# Altium schematic sheet Power.SchDoc(Power)
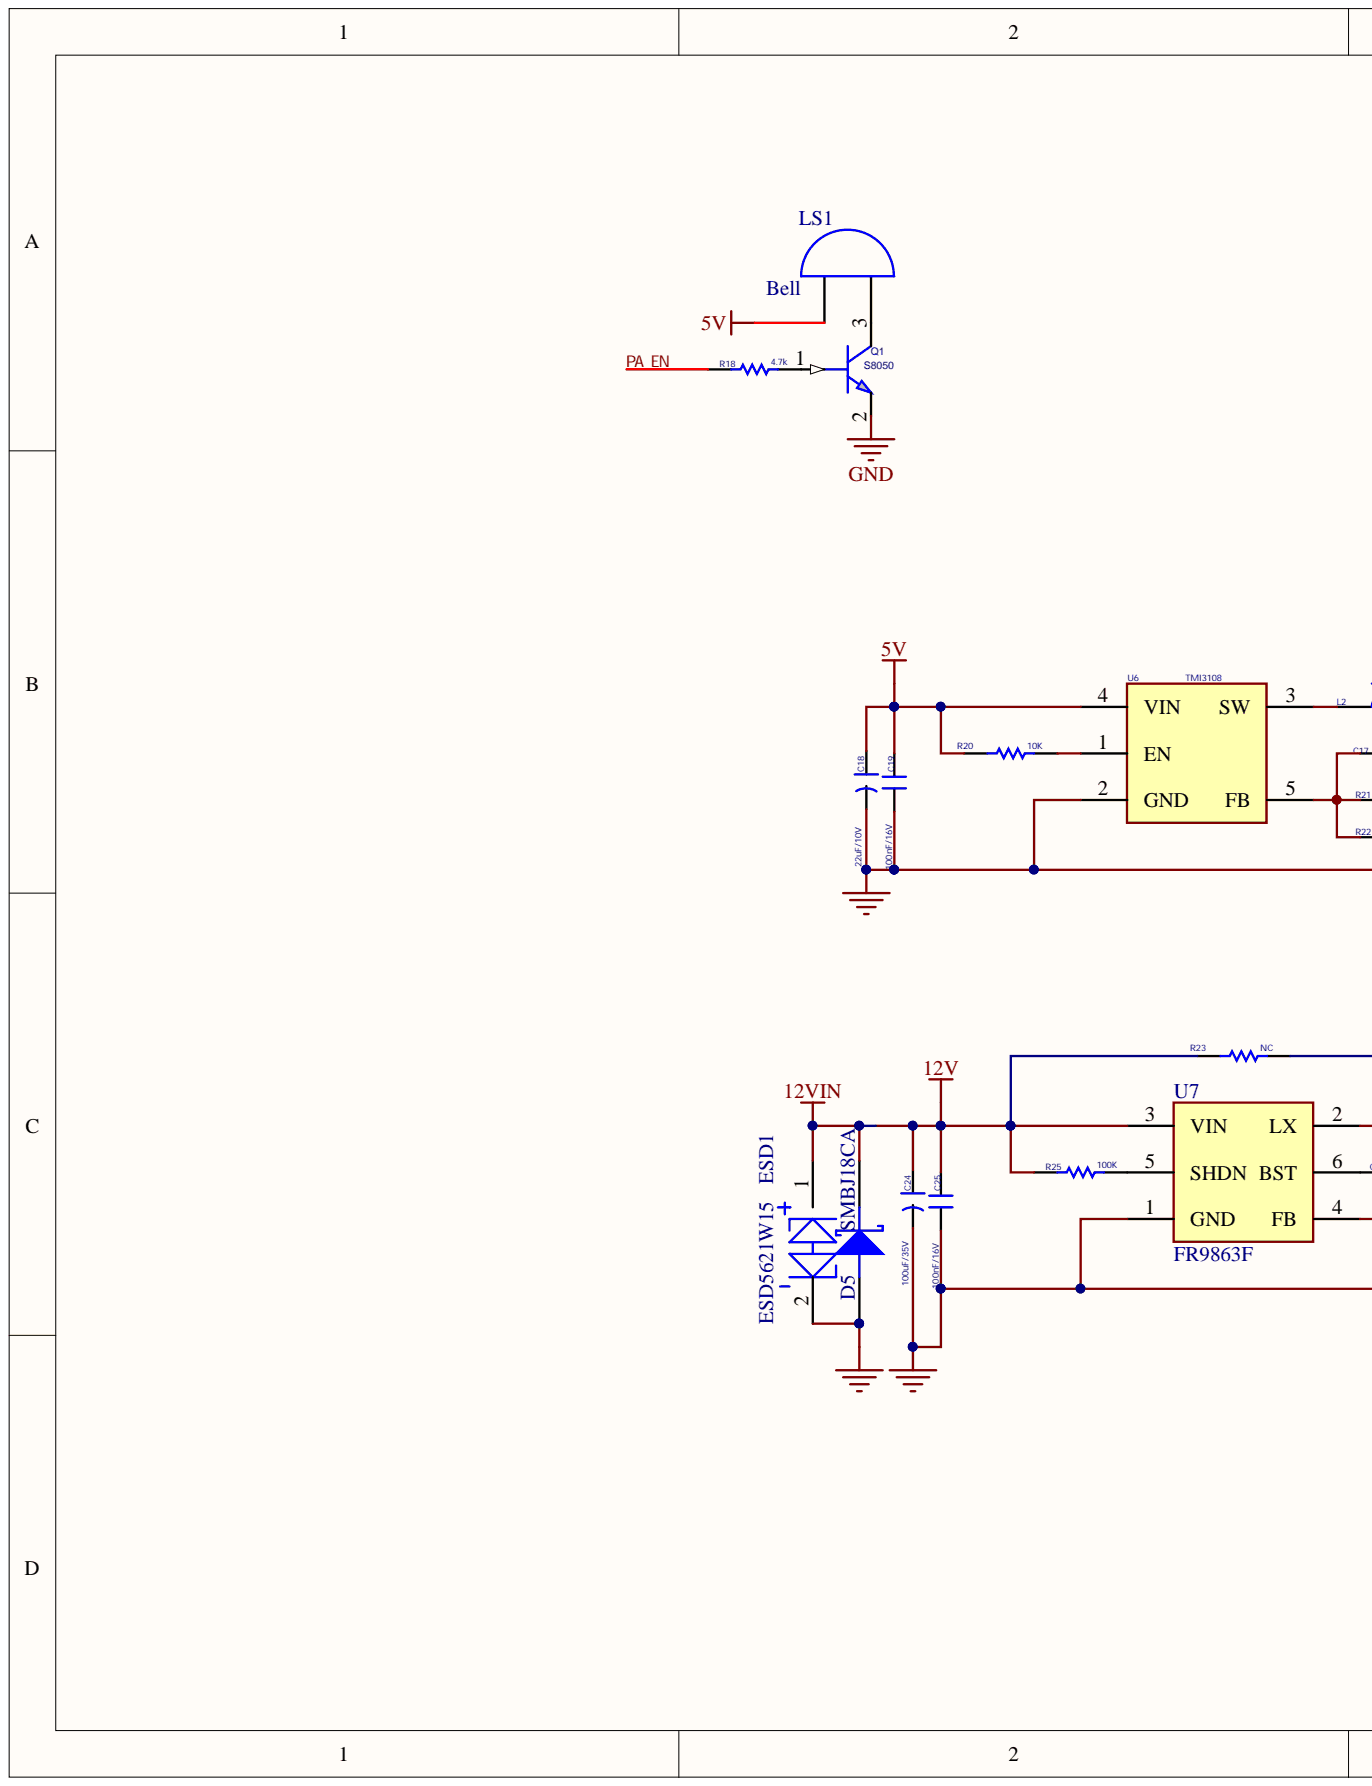
[diagram p0: Power.SchDoc, left]
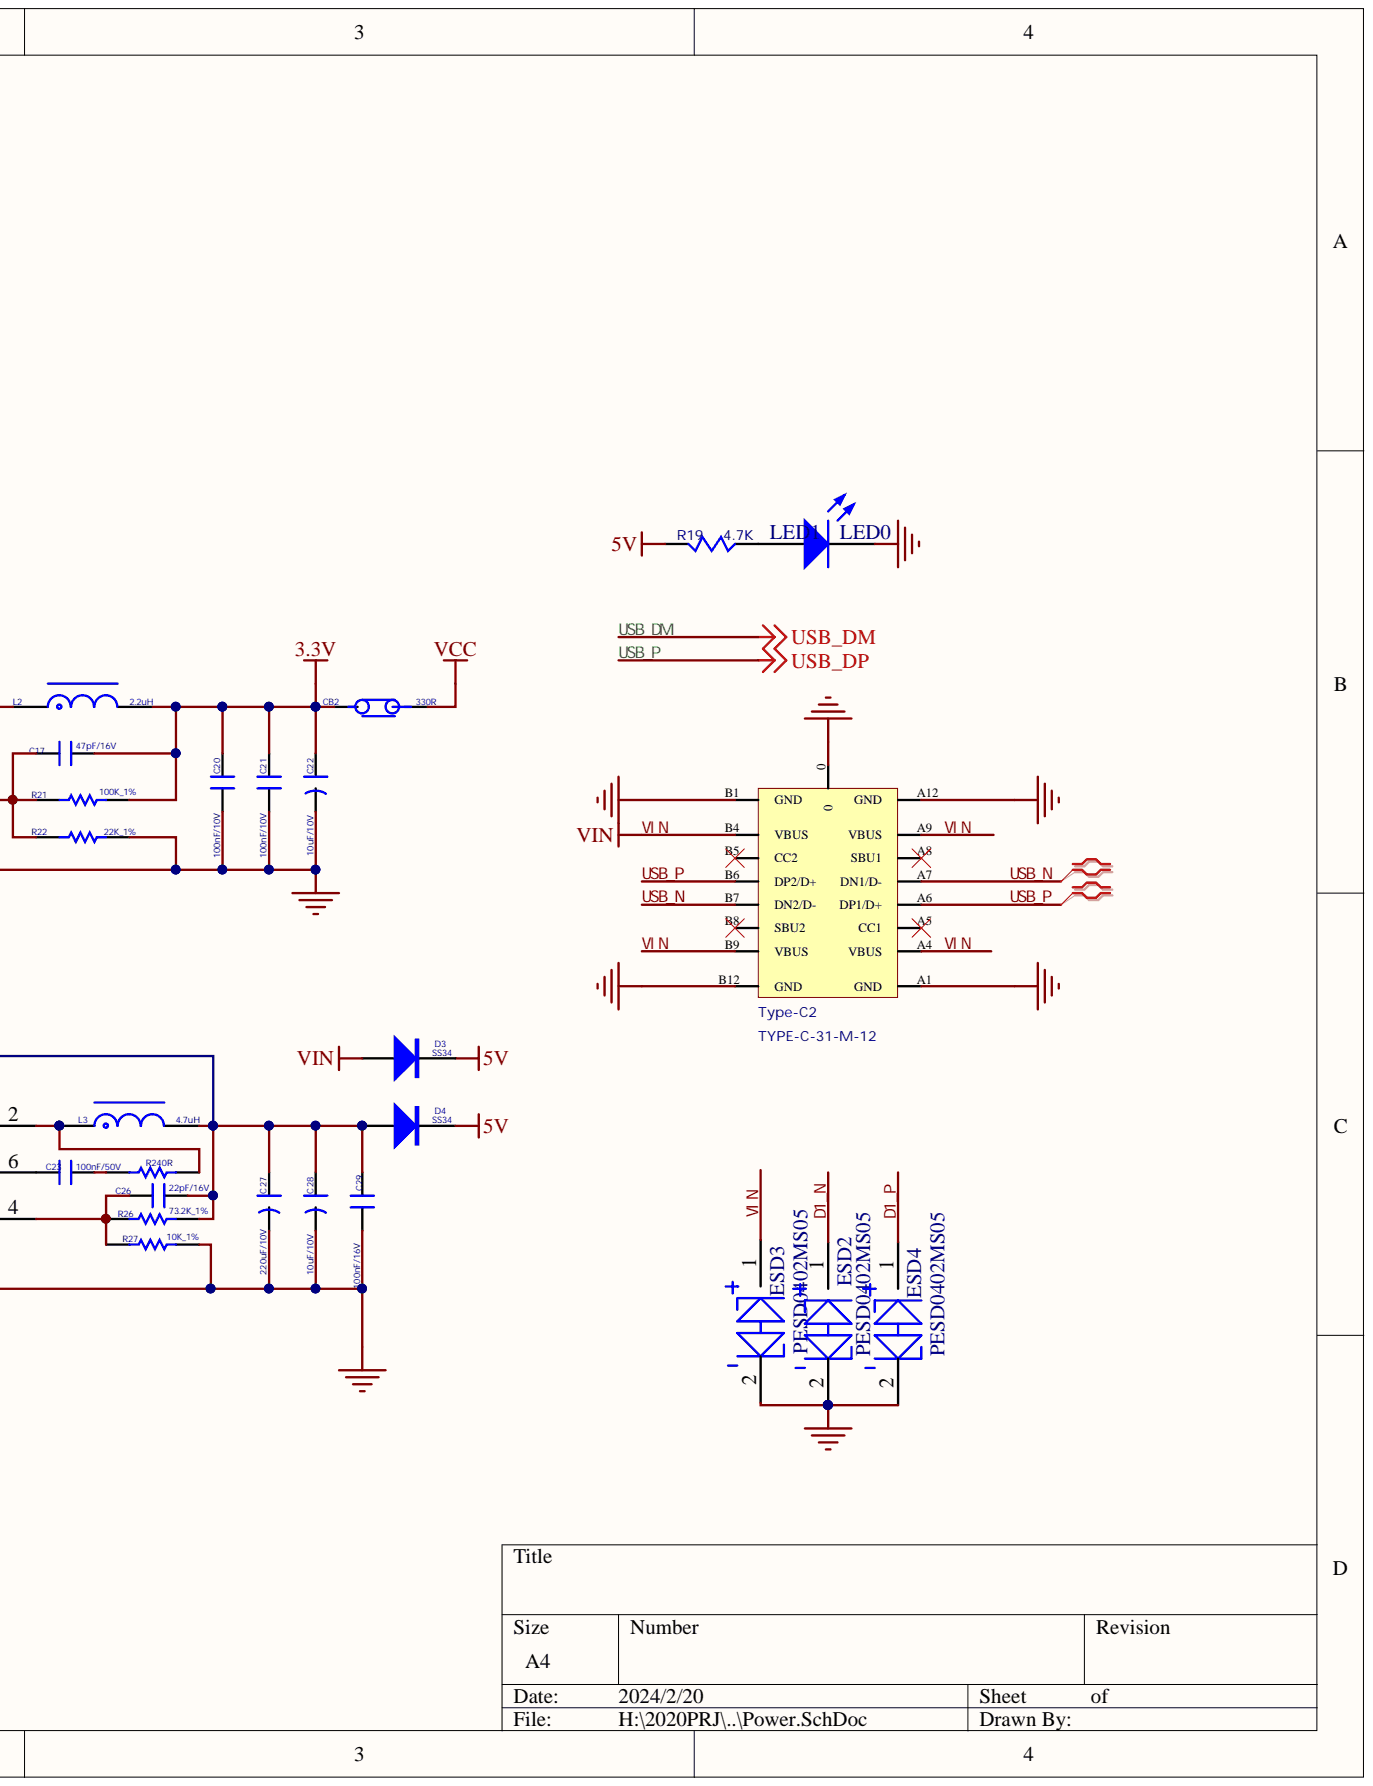
[diagram p1: Power.SchDoc, right]

## Parts
C17  47pF/16V  [C0402]
C18  22uF/10V  [1206C]
C19  100nF/16V  [C0402]
C20  100nF/10V  [C0402]
C21  100nF/10V  [C0402]
C22  10uF/10V  [C0603]
C23  100nF/50V  [C0402]
C24  100uF/35V  [CC6.56.5]
C25  100nF/16V  [C0402]
C26  22pF/16V  [C0402]
C27  220uF/10V  [CC6.56.5]
C28  10uF/10V  [C0603]
C29  100nF/16V  [C0402]
CB2  330R  [L0603]
D3  SS34  [ss14]
D4  SS34  [ss14]
D5  SMBJ18CA  [DO-214AA]
ESD1  ESD5621W15  [ESD5621W15]
ESD2  PESD0402MS05  [ESD0402]
ESD3  PESD0402MS05  [ESD0402]
ESD4  PESD0402MS05  [ESD0402]
L2  2.2uH  [SWPA5040S]
L3  4.7uH  [SWPA5040S]
LED1  LED0  [LED]
LS1  Bell  [bull]
Q1  S8050  [SOT-23]
R18  4.7k  [R0402]
R19  4.7K  [R0402]
R20  10K  [R0402]
R21  100K_1%  [R0402]
R22  22K_1%  [R0402]
R23  NC  [1206]
R24  0R  [R0402]  (value not drawn)
R25  100K  [R0402]
R26  73.2K_1%  [R0402]
R27  10K_1%  [R0402]
Type-C2  TYPE-C-31-M-12  [TYPE-C-12P]
U6  TMI3108  [SOT23-5]
U7  FR9863F  [CSOT23-6]

## Nets
3.3V: C17.1 C20.2 C21.2 C22.1 CB2.1 L2.2 R21.1
5V: C18.1 C19.2 D3.2 D4.2 LS1.1 R19.2 R20.1 U6.4(VIN)
12V: C24.1 C25.2 D5.2 ESD1.1 R23.1 R25.1 U7.3(VIN)
GND: C18.2 C19.1 C20.1 C21.1 C22.2 C24.2 C25.1 C27.2 C28.2 C29.1 D5.1 ESD1.2 ESD2.2 ESD3.2 ESD4.2 LED1.2 Q1.2 R22.2 R27.2 Type-C2.0 Type-C2.A1(GND) Type-C2.A12(GND) Type-C2.B1(GND) Type-C2.B12(GND) U6.2(GND) U7.1(GND)
VCC: CB2.2
D1_N: ESD2.1
D1_P: ESD4.1
PA_EN: R18.1
USB_N: Type-C2.A7(DN1/D-) Type-C2.B7(DN2/D-)
USB_P: Type-C2.A6(DP1/D+) Type-C2.B6(DP2/D+)
VIN: D3.1 ESD3.1 Type-C2.A4(VBUS) Type-C2.A9(VBUS) Type-C2.B4(VBUS) Type-C2.B9(VBUS)
NetC17_2: C17.2 R21.2 R22.1 U6.5(FB)
NetC23_1: C23.1 U7.6(BST)
NetC23_2: C23.2 R24.1
NetC26_1: C26.1 C27.1 C28.1 C29.2 D4.1 L3.2 R23.2 R26.1
NetC26_2: C26.2 R26.2 R27.1 U7.4(FB)
NetL2_1: L2.1 U6.3(SW)
NetL3_1: L3.1 R24.2 U7.2(LX)
NetLED1_1: LED1.1 R19.1
NetLS1_2: LS1.2 Q1.3
NetQ1_1: Q1.1 R18.2
NetR20_2: R20.2 U6.1(EN)
NetR25_2: R25.2 U7.5(SHDN)
USB_DM:

Not connected: Type-C2 A5(CC1) A8(SBU1) B5(CC2) B8(SBU2)

## Library data of the parts
C17 C19-C21 C23 C25 C26 C29 = CAPX: Component Kind: Standard; Library Name: JRP7813.SCHLIB
C18 C22 C24 C27 C28 = C-CS1A101M-CRD54: 贴片电容47uF_1206_10V; 品牌: ST(先科); 封装规格: SMD,5x5.4mm; Component Kind: Standard; 厂家型号: CS1A101M-CRD54
CB2 = CBW201209U331T: 贴片磁珠330R/100MHZ_500MA_0805; 品牌: FH(风华); 封装规格: 0805; Component Kind: Standard; 厂家型号: CBW201209U331T
D3 D4 = SS14: 1N5819_肖特基二极管_SMA; 品牌: ON(安森美); 封装规格: SMA(DO-214AC); Component Kind: Standard; 厂家型号: SS14
D5 = DIODE SCHOTTKY: Schottky Diode; Component Kind: Standard
ESD1-ESD4 = ESD5621W15: OR ROV20-180M; Component Kind: Standard
L2 L3 = L-LVS505020-2R2M-N: 贴片功率电感STWNR5020-2R2MT_5020_2.2uH; 品牌: chilisin(奇力新); 封装规格: 5050; Component Kind: Standard; 厂家型号: LVS505020-2R2M-N
LED1 = LED0: Typical INFRARED GaAs LED; Component Kind: Standard; LatestRevisionDate: 08-Jul-2005; LatestRevisionNote: Mech Layer 1 removed.; Library Name: Miscellaneous Devices.IntLib; PackageDescription: LED; 2 Leads; PackageReference: LED-0; Published: 8-Jun-2000; Simulation: LED0; Publisher: Altium Limited
LS1 = Bell: Electrical Bell; Component Kind: Standard; LatestRevisionDate: 17-Jul-2002; LatestRevisionNote: Re-released for DXP Platform.; Library Name: Miscellaneous Devices.IntLib; PackageReference: PIN2; Published: 24-Mar-1999; Publisher: Altium Limited
Q1 = NPN(BEC)_0: Component Kind: Standard
R18 R20-R27 = res1: Resistor; Value: 1K; Component Kind: Standard; LatestRevisionDate: 17-Jul-2002; LatestRevisionNote: Re-released for DXP Platform.; Library Name: Miscellaneous Devices.IntLib; PackageDescription: Axial Device, Thru-Hole; 2 Leads; 0.3 in Pin Spacing; PackageReference: AXIAL-0.3; Published: 8-Jun-2000; Signal Integrity: Res; Simulation: RESISTOR; Publisher: Altium Limited
R19 = RS-05L8R2JT: 贴片电阻; 品牌: FH(风华); 封装规格: 0805; Component Kind: Standard; 厂家型号: RS-05L8R2JT
Type-C2 = TYPE-C-31-M-12: 12pin type-c; Component Kind: Standard; Library Name: HLib.IntLib
U6 = U-SY8088AAC: 降压IC-TMI3108_SOT23-5; 品牌: 矽力杰silergy; 封装规格: SOT-23-5; Component Kind: Standard; 厂家型号: SY8088AAC
U7 = FR9863F: Component Kind: Standard; Library Name: eM713.SCHLIB
